# Altium schematic sheet Lobe_ESP32-S3.SchDoc
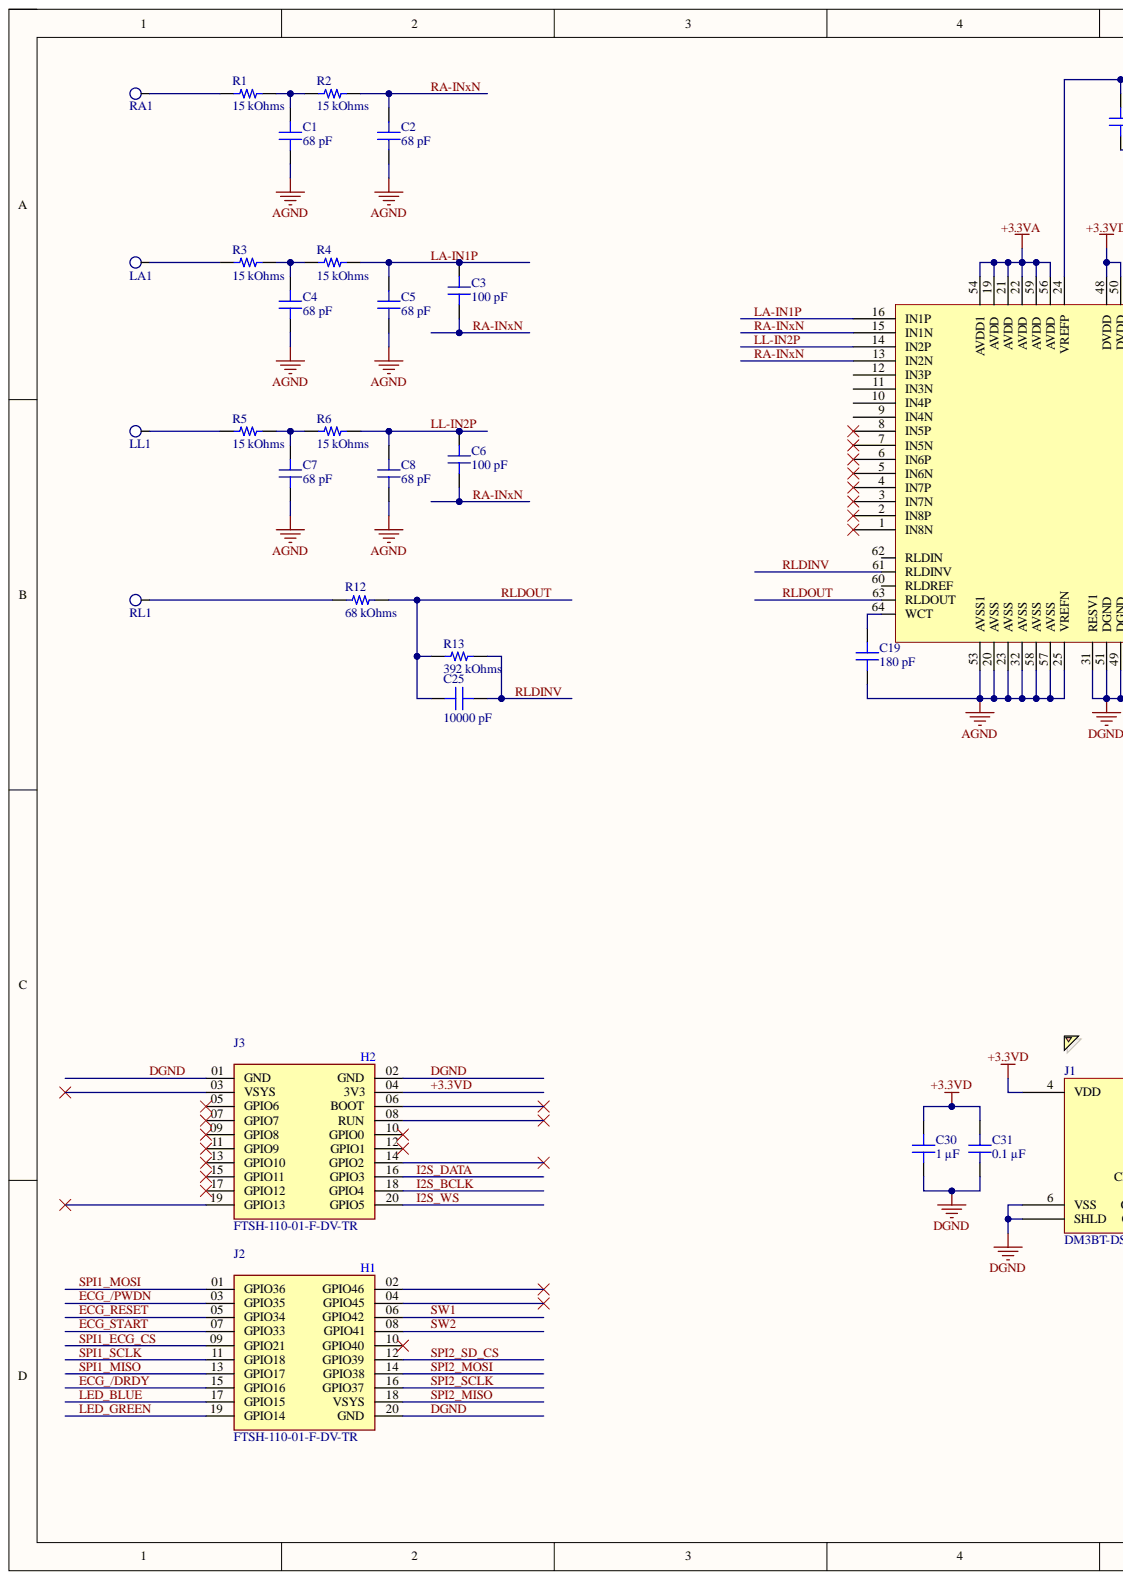
[diagram p0: Lobe_ESP32-S3.SchDoc, left]
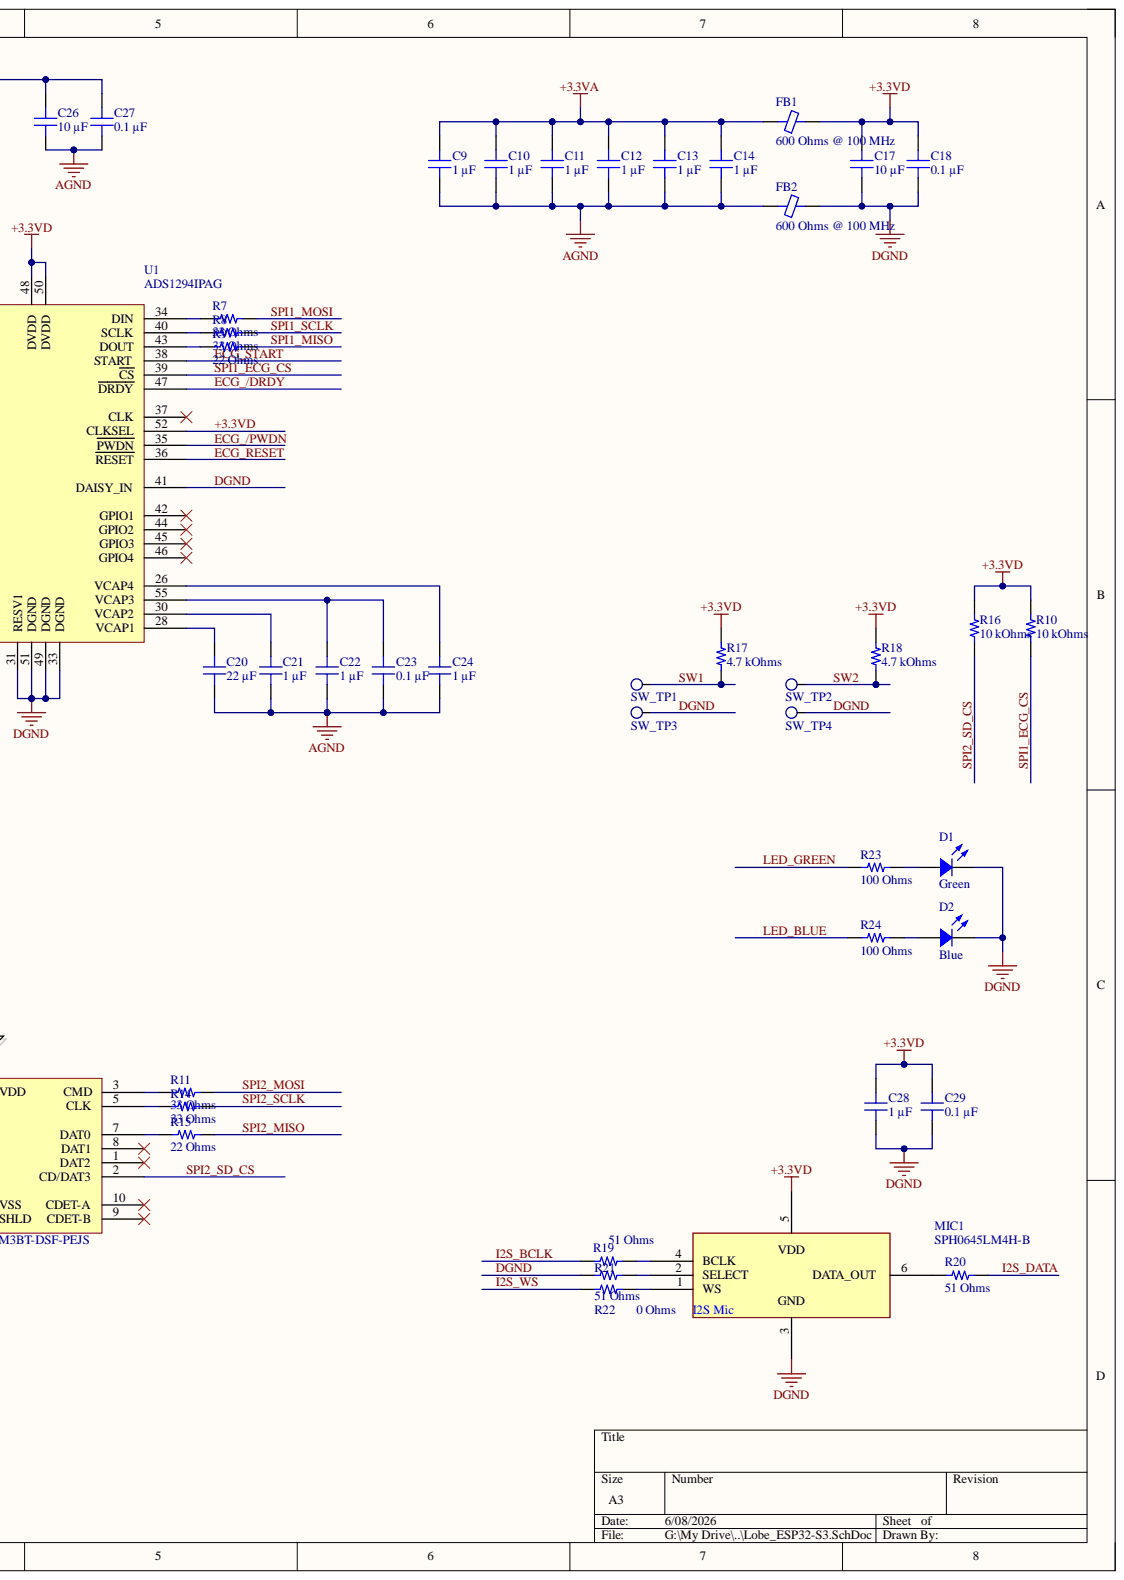
[diagram p1: Lobe_ESP32-S3.SchDoc, right]

## Parts
C1  68 pF  [CAP 0402_1005]
C2  68 pF  [CAP 0402_1005]
C3  100 pF  [CAP 0402_1005]
C4  68 pF  [CAP 0402_1005]
C5  68 pF  [CAP 0402_1005]
C6  100 pF  [CAP 0402_1005]
C7  68 pF  [CAP 0402_1005]
C8  68 pF  [CAP 0402_1005]
C9  1 µF  [CAP 0402_1005]
C10  1 µF  [CAP 0402_1005]
C11  1 µF  [CAP 0402_1005]
C12  1 µF  [CAP 0402_1005]
C13  1 µF  [CAP 0402_1005]
C14  1 µF  [CAP 0402_1005]
C17  10 µF  [CAP 0402_1005]
C18  0.1 µF  [CAP 0402_1005]
C19  180 pF  [CAP 0402_1005]
C20  22 µF  [CAP 0402_1005]
C21  1 µF  [CAP 0402_1005]
C22  1 µF  [CAP 0402_1005]
C23  0.1 µF  [CAP 0402_1005]
C24  1 µF  [CAP 0402_1005]
C25  10000 pF  [CAP 0402_1005]
C26  10 µF  [CAP 0402_1005]
C27  0.1 µF  [CAP 0402_1005]
C28  1 µF  [CAP 0402_1005]
C29  0.1 µF  [CAP 0402_1005]
C30  1 µF  [CAP 0402_1005]
C31  0.1 µF  [CAP 0402_1005]
D1  Green  [LED 0402_1005 GREEN]
D2  Blue  [LED 0402_1005 BLUE]
FB1  600 Ohms @ 100 MHz  [FER 0402_1005]
FB2  600 Ohms @ 100 MHz  [FER 0402_1005]
J1  DM3BT-DSF-PEJS  [HIROSE DM3BT-DSF-PEJS]
J2  FTSH-110-01-F-DV-TR  [SAMTEC_FTSH-110-01-F-DV-TR]
J3  FTSH-110-01-F-DV-TR  [SAMTEC_FTSH-110-01-F-DV-TR]
LA1  TestPoint  [PIAZZOLA]  (value not drawn)
LL1  TestPoint  [PIAZZOLA]  (value not drawn)
MIC1  SPH0645LM4H-B  [SPH0645LM4H-B_KNO]
R1  15 kOhms  [RES 0402_1005]
R2  15 kOhms  [RES 0402_1005]
R3  15 kOhms  [RES 0402_1005]
R4  15 kOhms  [RES 0402_1005]
R5  15 kOhms  [RES 0402_1005]
R6  15 kOhms  [RES 0402_1005]
R7  33 Ohms  [RES 0402_1005]
R8  33 Ohms  [RES 0402_1005]
R9  22 Ohms  [RES 0402_1005]
R10  10 kOhms  [RES 0402_1005]
R11  33 Ohms  [RES 0402_1005]
R12  68 kOhms  [RES 0402_1005]
R13  392 kOhms  [RES 0402_1005]
R14  33 Ohms  [RES 0402_1005]
R15  22 Ohms  [RES 0402_1005]
R16  10 kOhms  [RES 0402_1005]
R17  4.7 kOhms  [RES 0402_1005]
R18  4.7 kOhms  [RES 0402_1005]
R19  51 Ohms  [RES 0402_1005]
R20  51 Ohms  [RES 0402_1005]
R21  0 Ohms  [RES 0402_1005]
R22  51 Ohms  [RES 0402_1005]
R23  100 Ohms  [RES 0402_1005]
R24  100 Ohms  [RES 0402_1005]
RA1  TestPoint  [PIAZZOLA]  (value not drawn)
RL1  TestPoint  [PIAZZOLA]  (value not drawn)
SW_TP1  TestPoint  [PIAZZOLA]  (value not drawn)
SW_TP2  TestPoint  [PIAZZOLA]  (value not drawn)
SW_TP3  TestPoint  [PIAZZOLA]  (value not drawn)
SW_TP4  TestPoint  [PIAZZOLA]  (value not drawn)
U1  ADS1294IPAG  [TSQFP50P1200X1200X120-64N]

## Nets
+3.3VA: C9.2 C10.2 C11.2 C12.2 C13.2 C14.2 FB1.1 U1.19(AVDD) U1.21(AVDD) U1.22(AVDD) U1.54(AVDD1) U1.56(AVDD) U1.59(AVDD)
AGND: C1.1 C2.1 C4.1 C5.1 C7.1 C8.1 C9.1 C10.1 C11.1 C12.1 C13.1 C14.1 C19.1 C20.1 C21.1 C22.1 C23.1 C24.1 C26.1 C27.1 FB2.1 U1.20(AVSS) U1.23(AVSS) U1.25(VREFN) U1.32(AVSS) U1.53(AVSS1) U1.57(AVSS) U1.58(AVSS)
+3.3VD: C17.2 C18.2 C28.2 C29.2 C30.2 C31.2 FB1.2 J1.4(VDD) J3.04(3V3) MIC1.5 R10.2 R16.2 R17.2 R18.2 U1.48(DVDD) U1.50(DVDD) U1.52(CLKSEL)
DGND: C17.1 C18.1 C28.1 C29.1 C30.1 C31.1 D1.2 D2.2 FB2.2 J1.6(VSS) J1.S(SHLD) J2.20(GND) J3.01(GND) J3.02(GND) MIC1.3 R21.1 SW_TP3.1 SW_TP4.1 U1.31(RESV1) U1.33(DGND) U1.41(DAISY_IN) U1.49(DGND) U1.51(DGND)
ECG_/DRDY: J2.15(GPIO16) U1.47(DRDY)
ECG_/PWDN: J2.03(GPIO35) U1.35(PWDN)
ECG_RESET: J2.05(GPIO34) U1.36(RESET)
ECG_START: J2.07(GPIO33) U1.38(START)
I2S_BCLK: J3.18(GPIO4) R19.1
I2S_DATA: J3.16(GPIO3) R20.2
I2S_WS: J3.20(GPIO5) R22.1
LA-IN1P: C3.2 C5.2 R4.2 U1.16(IN1P)
LED_BLUE: J2.17(GPIO15) R24.1
LED_GREEN: J2.19(GPIO14) R23.1
LL-IN2P: C6.2 C8.2 R6.2 U1.14(IN2P)
RA-INxN: C2.2 C3.1 C6.1 R2.2 U1.13(IN2N) U1.15(IN1N)
RLDINV: C25.2 R13.2 U1.61(RLDINV)
RLDOUT: C25.1 R12.2 R13.1 U1.63(RLDOUT)
SPI1_ECG_CS: J2.09(GPIO21) R10.1 U1.39(CS)
SPI1_MISO: J2.13(GPIO17) R9.2
SPI1_MOSI: J2.01(GPIO36) R7.2
SPI1_SCLK: J2.11(GPIO18) R8.2
SPI2_MISO: J2.18(VSYS) R15.2
SPI2_MOSI: J2.14(GPIO38) R11.2
SPI2_SCLK: J2.16(GPIO37) R14.2
SPI2_SD_CS: J1.2(CD/DAT3) J2.12(GPIO39) R16.1
SW1: J2.06(GPIO42) R17.1 SW_TP1.1
SW2: J2.08(GPIO41) R18.1 SW_TP2.1
NetC1_2: C1.2 R1.2 R2.1
NetC4_2: C4.2 R3.2 R4.1
NetC7_2: C7.2 R5.2 R6.1
NetC19_2: C19.2 U1.64(WCT)
NetC20_2: C20.2 U1.28(VCAP1)
NetC21_2: C21.2 U1.30(VCAP2)
NetC22_2: C22.2 C23.2 U1.55(VCAP3)
NetC24_2: C24.2 U1.26(VCAP4)
NetC26_2: C26.2 C27.2 U1.24(VREFP)
NetD1_1: D1.1 R23.2
NetD2_1: D2.1 R24.2
NetJ1_3: J1.3(CMD) R11.1
NetJ1_5: J1.5(CLK) R14.1
NetJ1_7: J1.7(DAT0) R15.1
NetLA1_1: LA1.1 R3.1
NetLL1_1: LL1.1 R5.1
NetMIC1_1: MIC1.1(WS) R22.2
NetMIC1_2: MIC1.2(SELECT) R21.2
NetMIC1_4: MIC1.4(BCLK) R19.2
NetMIC1_6: MIC1.6(DATA_OUT) R20.1
NetR1_1: R1.1 RA1.1
NetR7_1: R7.1 U1.34(DIN)
NetR8_1: R8.1 U1.40(SCLK)
NetR9_1: R9.1 U1.43(DOUT)
NetR12_1: R12.1 RL1.1

Not connected: J1 1(DAT2) 8(DAT1) 9(CDET-B) 10(CDET-A); J2 02(GPIO46) 04(GPIO45) 10(GPIO40); J3 03(VSYS) 05(GPIO6) 06(BOOT) 07(GPIO7) 08(RUN) 09(GPIO8) 10(GPIO0) 11(GPIO9) 12(GPIO1) 13(GPIO10) 14(GPIO2) 15(GPIO11) 17(GPIO12) 19(GPIO13); U1 1(IN8N) 2(IN8P) 3(IN7N) 4(IN7P) 5(IN6N) 6(IN6P) 7(IN5N) 8(IN5P) 9(IN4N) 10(IN4P) 11(IN3N) 12(IN3P) 37(CLK) 42(GPIO1) 44(GPIO2) 45(GPIO3) 46(GPIO4) 60(RLDREF) 62(RLDIN)

## Library data of the parts
C1 C2 C4 C5 C7 C8 = C0402C680J5GACAUTO: CAP CER 68PF 50V C0G/NP0 0402; manufacturer: KEMET; Database Table Name: Capacitors - Ceramic - 0402; Temperature Coefficient: C0G, NP0; Tolerance: ±5%; Applications: Automotive; Capacitance: 68 pF; Component Kind: Standard; componentlink1description: Datasheet; componentlink1url: https://api.kemet.com/component-edge/download/datasheet/C0402C680J5GACAUTO.pdf; componentlink2description: DigiKey Link; componentlink2url: https://www.digikey.com/en/products/detail/kemet/C0402C680J5GACAUTO/7516109; Device Package: 0402 (1005 Metric); Features: Low ESL; lastupdated: 05/04/2026 19:11:09; Library Name: Celestial Altium Library - altium_library.DbLib; Lifecycle Status: Active; Minimum Order: 1; Mounting Type: Surface Mount, MLCC; Operating Temperature: -55°C ~ 125°C; Package / Case: 0402 (1005 Metric); packaging: TapeAndReel; Part Number: C0402C680J5GACAUTO; Part Status: Active; partid: 2086; price: 0.01; Ratings: AEC-Q200; series: SMD Auto C0G; Size / Dimension: 0.039" L x 0.020" W (1.00mm x 0.50mm); Supplier 1: DigiKey; Supplier Part Number 1: 399-15875-1-ND; Thickness (Max): 0.022" (0.55mm); value: 68 pF; Voltage - Rated: 50V
C3 C6 = C0402C101F3GAC7867: CAP CER 100PF 25V C0G/NP0 0402; manufacturer: KEMET; Database Table Name: Capacitors - Ceramic - 0402; Temperature Coefficient: C0G, NP0; Tolerance: ±1%; Applications: General Purpose; Capacitance: 100 pF; Component Kind: Standard; componentlink1description: Datasheet; componentlink1url: https://api.kemet.com/component-edge/download/datasheet/C0402C101F3GACTU.pdf; componentlink2description: DigiKey Link; componentlink2url: https://www.digikey.com/en/products/detail/kemet/C0402C101F3GAC7867/2196160; Device Package: 0402 (1005 Metric); Features: Low ESL; lastupdated: 05/04/2026 19:10:07; Library Name: Celestial Altium Library - altium_library.DbLib; Lifecycle Status: Active; Minimum Order: 1; Mounting Type: Surface Mount, MLCC; Operating Temperature: -55°C ~ 125°C; Package / Case: 0402 (1005 Metric); packaging: TapeAndReel; Part Number: C0402C101F3GAC7867; Part Status: Active; partid: 33146; price: 0.39; series: SMD Comm C0G; Size / Dimension: 0.039" L x 0.020" W (1.00mm x 0.50mm); Supplier 1: DigiKey; Supplier Part Number 1: 399-C0402C101F3GAC7867CT-ND; Thickness (Max): 0.022" (0.55mm); value: 100 pF; Voltage - Rated: 25V
C9-C14 C21 C22 C24 C28 C30 = GMC04X5R105K50NT: CAP0402 X5R 1UF 10% 50V; manufacturer: Cal-Chip Electronics, Inc.; Database Table Name: Capacitors - Ceramic - 0402; Applications: General Purpose; Capacitance: 1 µF; Component Kind: Standard; componentlink1description: Datasheet; componentlink1url: https://calchip.com/wp-content/uploads/2023/05/gmc_series.pdf; componentlink2description: DigiKey Link; componentlink2url: https://www.digikey.com/en/products/detail/cal-chip-electronics-inc/GMC04X5R105K50NT/21802043; Device Package: 0402 (1005 Metric); lastupdated: 05/04/2026 19:07:28; Library Name: Celestial Altium Library - altium_library.DbLib; Lifecycle Status: Active; Minimum Order: 1; Mounting Type: Surface Mount, MLCC; Operating Temperature: -55°C ~ 85°C; Package / Case: 0402 (1005 Metric); packaging: TapeAndReel; Part Number: GMC04X5R105K50NT; partid: 63715; price: 0.02; series: GMC; Size / Dimension: 0.039" L x 0.020" W (1.00mm x 0.50mm); Supplier 1: DigiKey; Supplier Part Number 1: 4713-GMC04X5R105K50NTCT-ND; Temperature Coefficient: X5R; Thickness (Max): 0.022" (0.55mm); Tolerance: ±10%; value: 1 µF; Voltage - Rated: 50V
C17 C26 = C0402C106M9PAC7867: CAP CER 10UF 6.3V X5R 0402; manufacturer: KEMET; Database Table Name: Capacitors - Ceramic - 0402; Tolerance: ±20%; Applications: Bypass, Decoupling; Capacitance: 10 µF; Component Kind: Standard; componentlink1description: Datasheet; componentlink1url: https://api.kemet.com/component-edge/download/datasheet/C0402C106M9PAC7867.pdf; componentlink2description: DigiKey Link; componentlink2url: https://www.digikey.com/en/products/detail/kemet/C0402C106M9PAC7867/5767552; Device Package: 0402 (1005 Metric); lastupdated: 05/04/2026 19:11:04; Library Name: Celestial Altium Library - altium_library.DbLib; Lifecycle Status: Active; Minimum Order: 1; Mounting Type: Surface Mount, MLCC; Operating Temperature: -55°C ~ 85°C; Package / Case: 0402 (1005 Metric); packaging: TapeAndReel; Part Number: C0402C106M9PAC7867; Part Status: Active; partid: 41493; price: 0.12; series: SMD Comm X5R; Size / Dimension: 0.039" L x 0.020" W (1.00mm x 0.50mm); Supplier 1: DigiKey; Supplier Part Number 1: 399-13069-1-ND; Temperature Coefficient: X5R; Thickness (Max): 0.028" (0.70mm); value: 10 µF; Voltage - Rated: 6.3V
C18 C23 C27 C29 C31 = CL05B104KP5VPNC: CAP CER 100NF 10V X7R 0402; manufacturer: Samsung Electro-Mechanics; Database Table Name: Capacitors - Ceramic - 0402; Tolerance: ±10%; Applications: Automotive; Capacitance: 0.1 µF; Component Kind: Standard; componentlink1description: Datasheet; componentlink1url: https://mm.digikey.com/Volume0/opasdata/d220001/medias/docus/5458/CL05B104KP5VPNC_Spec.pdf; componentlink2description: DigiKey Link; componentlink2url: https://www.digikey.com/en/products/detail/samsung-electro-mechanics/CL05B104KP5VPNC/20498482; Device Package: 0402 (1005 Metric); Features: General Purpose; lastupdated: 05/04/2026 19:07:41; Library Name: Celestial Altium Library - altium_library.DbLib; Lifecycle Status: Active; Minimum Order: 1; Mounting Type: Surface Mount, MLCC, Epoxy; Operating Temperature: -55°C ~ 125°C; Package / Case: 0402 (1005 Metric); packaging: TapeAndReel; Part Number: CL05B104KP5VPNC; partid: 56690; price: 0.01; Ratings: AEC-Q200; series: CL; Size / Dimension: 0.039" L x 0.020" W (1.00mm x 0.50mm); Supplier 1: DigiKey; Supplier Part Number 1: 1276-CL05B104KP5VPNCCT-ND; Temperature Coefficient: X7R; Thickness (Max): 0.022" (0.55mm); value: 0.1 µF; Voltage - Rated: 10V
C19 = C0402C181J5GACAUTO: CAP CER 180PF 50V C0G/NP0 0402; manufacturer: KEMET; Database Table Name: Capacitors - Ceramic - 0402; Temperature Coefficient: C0G, NP0; Tolerance: ±5%; Applications: Automotive; Capacitance: 180 pF; Component Kind: Standard; componentlink1description: Datasheet; componentlink1url: https://api.kemet.com/component-edge/download/datasheet/C0402C181J5GACAUTO.pdf; componentlink2description: DigiKey Link; componentlink2url: https://www.digikey.com/en/products/detail/kemet/C0402C181J5GACAUTO/3314831; Device Package: 0402 (1005 Metric); Features: Low ESL; lastupdated: 05/04/2026 19:10:56; Library Name: Celestial Altium Library - altium_library.DbLib; Lifecycle Status: Active; Minimum Order: 1; Mounting Type: Surface Mount, MLCC; Operating Temperature: -55°C ~ 125°C; Package / Case: 0402 (1005 Metric); packaging: TapeAndReel; Part Number: C0402C181J5GACAUTO; Part Status: Active; partid: 5144; price: 0.01; Ratings: AEC-Q200; series: SMD Auto C0G; Size / Dimension: 0.039" L x 0.020" W (1.00mm x 0.50mm); Supplier 1: DigiKey; Supplier Part Number 1: 399-6832-1-ND; Thickness (Max): 0.022" (0.55mm); value: 180 pF; Voltage - Rated: 50V
C20 = GMC04X5R226M6R3NT: CAP0402 X5R 22UF 20% 6.3V; manufacturer: Cal-Chip Electronics, Inc.; Database Table Name: Capacitors - Ceramic - 0402; Applications: General Purpose; Capacitance: 22 µF; Component Kind: Standard; componentlink1description: Datasheet; componentlink1url: https://88ce57.p3cdn1.secureserver.net/wp-content/uploads/2023/01/gmc_series-2.pdf; componentlink2description: DigiKey Link; componentlink2url: https://www.digikey.com/en/products/detail/cal-chip-electronics-inc/GMC04X5R226M6R3NT/18151129; Device Package: 0402 (1005 Metric); lastupdated: 05/04/2026 19:09:29; Library Name: Celestial Altium Library - altium_library.DbLib; Lifecycle Status: Active; Minimum Order: 1; Mounting Type: Surface Mount, MLCC; Operating Temperature: -55°C ~ 85°C; Package / Case: 0402 (1005 Metric); packaging: TapeAndReel; Part Number: GMC04X5R226M6R3NT; Part Status: Active; partid: 49823; price: 0.08; series: GMC; Size / Dimension: 0.039" L x 0.020" W (1.00mm x 0.50mm); Supplier 1: DigiKey; Supplier Part Number 1: 4713-GMC04X5R226M6R3NTCT-ND; Temperature Coefficient: X5R; Thickness (Max): 0.031" (0.80mm); Tolerance: ±20%; value: 22 µF; Voltage - Rated: 6.3V
C25 = C0402C103J3RECAUTO: CAP CER 0402 10NF 25V X7R 5%; manufacturer: KEMET; Database Table Name: Capacitors - Ceramic - 0402; Applications: Automotive, ESD Protection; Capacitance: 10000 pF; Component Kind: Standard; componentlink1description: Datasheet; componentlink1url: https://connect.kemet.com:7667/gateway/IntelliData-ComponentDocumentation/1.0/download/datasheet/C0402C103J3RECAUTO; componentlink2description: DigiKey Link; componentlink2url: https://www.digikey.com/en/products/detail/kemet/C0402C103J3RECAUTO/8646437; Device Package: 0402 (1005 Metric); lastupdated: 05/04/2026 19:07:35; Library Name: Celestial Altium Library - altium_library.DbLib; Lifecycle Status: Active; Minimum Order: 1; Mounting Type: Surface Mount, MLCC; Operating Temperature: -55°C ~ 125°C; Package / Case: 0402 (1005 Metric); packaging: TapeAndReel; Part Number: C0402C103J3RECAUTO; partid: 64019; price: 0.02; Ratings: AEC-Q200; series: ESD SMD Auto X7R; Size / Dimension: 0.039" L x 0.020" W (1.00mm x 0.50mm); Supplier 1: DigiKey; Supplier Part Number 1: 399-C0402C103J3RECAUTOCT-ND; Temperature Coefficient: X7R; Thickness (Max): 0.022" (0.55mm); Tolerance: ±5%; value: 10000 pF; Voltage - Rated: 25V
D1 = SMLP14ECNWT86: LED GREEN DIFFUSED 0402 SMD; Manufacturer: Rohm Semiconductor; Current - Test: 5mA; Database Table Name: LED - Indication; Lens Color: White; Lens Size: 1.00mm x 0.60mm; Lens Style: Rectangle with Flat Top; Millicandela Rating: 110mcd; Voltage - Forward (Vf) (Typ): 3V; Wavelength - Dominant: 527nm; Color: Green; Component Kind: Standard; ComponentLink1Description: Datasheet; ComponentLink1URL: https://fscdn.rohm.com/en/products/databook/datasheet/opto/led/chip_mono/smlp14ecnwt86-e.pdf; ComponentLink2Description: DigiKey Link; ComponentLink2URL: https://www.digikey.com/en/products/detail/rohm-semiconductor/SMLP14ECNWT86/16808213; Device Package: 0402 (1005 Metric); Height (Max): 0.25mm; LastUpdated: 05/04/2026 20:35:37; Lens Transparency: Diffused; Library Name: Celestial Altium Library - altium_library.DbLib; Lifecycle Status: Active; Minimum Order: 1; Mounting Type: Surface Mount; Package / Case: 0402 (1005 Metric); Packaging: TapeAndReel; Part Number: SMLP14ECNWT86; Part Status: Active; PartId: 1225; Price: 0.34; Series: -; Size / Dimension: 1.00mm L x 0.60mm W; Supplier 1: DigiKey; Supplier Device Package: 0402; Supplier Part Number 1: 846-SMLP14ECNWT86CT-ND; Value: Green
D2 = SMLP14BCNWT86: LED BLUE DIFFUSED 0402 SMD; Manufacturer: Rohm Semiconductor; Current - Test: 5mA; Database Table Name: LED - Indication; Lens Color: White; Lens Size: 1.00mm x 0.60mm; Lens Style: Rectangle with Flat Top; Millicandela Rating: 25mcd; Voltage - Forward (Vf) (Typ): 2.9V; Wavelength - Dominant: 470nm; Color: Blue; Component Kind: Standard; ComponentLink2Description: DigiKey Link; ComponentLink2URL: https://www.digikey.com/en/products/detail/rohm-semiconductor/SMLP14BCNWT86/15792854; Device Package: 0402 (1005 Metric); Height (Max): 0.25mm; LastUpdated: 05/04/2026 20:34:30; Lens Transparency: Diffused; Library Name: Celestial Altium Library - altium_library.DbLib; Lifecycle Status: Active; Minimum Order: 1; Mounting Type: Surface Mount; Package / Case: 0402 (1005 Metric); Packaging: TapeAndReel; Part Number: SMLP14BCNWT86; Part Status: Active; PartId: 1297; Price: 0.17; Series: -; Size / Dimension: 1.00mm L x 0.60mm W; Supplier 1: DigiKey; Supplier Device Package: 0402; Supplier Part Number 1: 846-SMLP14BCNWT86CT-ND; Value: Blue
FB1 FB2 = BLM15PX601SN1D: FERRITE BEAD 600 OHM 0402 1LN; Manufacturer: Murata Electronics; Current Rating (Max): 900mA; Database Table Name: Filters - Ferrite Beads and Chips; DC Resistance (DCR) (Max): 230mOhm; Filter Type: Power Line; Number of Lines: 1; Component Kind: Standard; ComponentLink1Description: Datasheet; ComponentLink1URL: https://www.murata.com/en-us/products/productdata/8796740059166/ENFA0018.pdf; ComponentLink2Description: DigiKey Link; ComponentLink2URL: https://www.digikey.com/en/products/detail/murata-electronics/BLM15PX601SN1D/4421102; Device Package: 0402 (1005 Metric); Height (Max): 0.022" (0.55mm); Impedance @ Frequency: 600 Ohms @ 100 MHz; LastUpdated: 05/04/2026 20:27:16; Library Name: Celestial Altium Library - altium_library.DbLib; Lifecycle Status: Active; Minimum Order: 1; Mounting Type: Surface Mount; Operating Temperature: -55°C ~ 125°C; Package / Case: 0402 (1005 Metric); Packaging: TapeAndReel; Part Number: BLM15PX601SN1D; Part Status: Active; PartId: 188; Price: 0.02; Series: EMIFIL®, BLM15; Size / Dimension: 0.039" L x 0.022" W (1.00mm x 0.55mm); Supplier 1: DigiKey; Supplier Part Number 1: 490-9657-1-ND; Value: 600 Ohms @ 100 MHz
J1 = DM3BT-DSF-PEJS: CONN MICRO SD CARD PUSH-PUSH R/A; Manufacturer: Hirose Electric Co Ltd; Card Type: Secure Digital - microSD™; Connector Type: Connector and Ejector; Database Table Name: Connectors - Memory - Card Sockets; Insertion, Removal Method: Push In, Push Out; Number of Positions: 10 (8 + 2); Component Kind: Standard; ComponentLink1Description: Datasheet; ComponentLink1URL: https://www.hirose.com/product/download/?distributor=digikey&type=specSheet&lang=en&num=DM3BT-DSF-PEJS; ComponentLink2Description: DigiKey Link; ComponentLink2URL: //digikey.com/product-detail/en/hirose-electric-co-ltd/DM3BT-DSF-PEJS/HR1942TR-ND/1982749; Contact Finish: Gold; Features: Switch; Height Above Board: 0.072" (1.83mm); LastUpdated: 16/12/2019 20:35:34; Library Name: Celestial Altium Library - altium_library.DbLib; Lifecycle Status: 0; Minimum Order: 1; Mounting Feature: Reverse - Bottom; Mounting Type: Surface Mount, Right Angle; Packaging: TapeAndReel; Part Number: DM3BT-DSF-PEJS; Part Status: Active; Price: 1.25; Series: DM3; Supplier 1: DigiKey; Supplier Part Number 1: HR1942TR-ND
J2 = H1-ESP32-S3-LCD-1.28: Connector Header Surface Mount 20 position 0.050 (1.27mm); MF: Samtec; MP: FTSH-110-01-F-DV-P-TR; Check_prices: https://www.snapeda.com/parts/FTSH-110-01-F-DV-P-TR/Samtec%20Inc./view-part/2789086/?ref=eda; Component Kind: Standard; Digi-Key_Part_Number: SAM13954CT-ND; Package: None; Purchase-URL: https://pricing.snapeda.com/search/part/FTSH-110-01-F-DV-P-TR/?ref=eda; SnapEDA_Link: https://www.snapeda.com/parts/FTSH-110-01-F-DV-P-TR/Samtec%20Inc./view-part/2789086/?ref=snap; Library Name: Lobe_Lib.SchLib
J3 = H2-ESP32-S3-LCD-1.28: Connector Header Surface Mount 20 position 0.050 (1.27mm); MF: Samtec; MP: FTSH-110-01-F-DV-P-TR; Check_prices: https://www.snapeda.com/parts/FTSH-110-01-F-DV-P-TR/Samtec%20Inc./view-part/2789086/?ref=eda; Component Kind: Standard; Digi-Key_Part_Number: SAM13954CT-ND; Package: None; Purchase-URL: https://pricing.snapeda.com/search/part/FTSH-110-01-F-DV-P-TR/?ref=eda; SnapEDA_Link: https://www.snapeda.com/parts/FTSH-110-01-F-DV-P-TR/Samtec%20Inc./view-part/2789086/?ref=snap; Library Name: Lobe_Lib.SchLib
MIC1 = SPH0645LM4H-B: No Description Available; Manufacturer_Name: Knowles; Component Kind: Standard; Manufacturer_Part_Number: SPH0645LM4H-B; RefDes: RefDes; Type: Type; Copyright: Copyright (C) 2026 Ultra Librarian. All rights reserved.; Library Name: Lobe_Lib.SchLib
R1-R6 = AC0402FR-0715KL: RES SMD 15K OHM 1% 1/16W 0402; Manufacturer: Yageo; Database Table Name: Resistors - Surface Mount - 0402; Power (Watts): 0.063W, 1/16W; Temperature Coefficient: ±100ppm/°C; Component Kind: Standard; ComponentLink1Description: Datasheet; ComponentLink1URL: https://www.yageo.com/upload/media/product/productsearch/datasheet/rchip/PYu-AC_51_RoHS_L_7.pdf; ComponentLink2Description: DigiKey Link; ComponentLink2URL: https://www.digikey.com/en/products/detail/yageo/AC0402FR-0715KL/5895086; Composition: Thick Film; Device Package: 0402 (1005 Metric); Features: Automotive AEC-Q200, Moisture Resistant; Height - Seated (Max): 0.015" (0.37mm); LastUpdated: 06/04/2026 19:41:11; Library Name: Celestial Altium Library - altium_library.DbLib; Lifecycle Status: Active; Minimum Order: 1; Number of Terminations: 2; Operating Temperature: -55°C ~ 155°C; Package / Case: 0402 (1005 Metric); Packaging: CutTape; Part Number: AC0402FR-0715KL; Part Status: Active; PartId: 12138; Price: 0.01; Ratings: AEC-Q200; Resistance: 15 kOhms; Series: AC; Size / Dimension: 0.039" L x 0.020" W (1.00mm x 0.50mm); Supplier 1: DigiKey; Supplier Device Package: 0402; Supplier Part Number 1: YAG3444CT-ND; Tolerance: ±1%; Value: 15 kOhms
R7 R8 R11 R14 = RC0402FR-0733RP: RES 33 OHM 1% 1/16W 0402; Manufacturer: Yageo; Database Table Name: Resistors - Surface Mount - 0402; Power (Watts): 0.063W, 1/16W; Ratings: 7C1 RoHS w/out Exemption (100% Pb-Free); Temperature Coefficient: ±100ppm/°C; Component Kind: Standard; ComponentLink1Description: Datasheet; ComponentLink1URL: http://www.yageo.com/NewPortal/yageodocoutput?fileName=/pdf/R-Chip/PYu-RC_51_RoHS_P_0.pdf; ComponentLink2Description: DigiKey Link; ComponentLink2URL: https://www.digikey.com/en/products/detail/yageo/RC0402FR-0733RP/4935279; Composition: Thick Film; Device Package: 0402 (1005 Metric); Features: Moisture Resistant; Height - Seated (Max): 0.016" (0.40mm); LastUpdated: 06/04/2026 19:41:12; Library Name: Celestial Altium Library - altium_library.DbLib; Lifecycle Status: Active; Minimum Order: 1; Number of Terminations: 2; Operating Temperature: -55°C ~ 155°C; Package / Case: 0402 (1005 Metric); Packaging: CutTape; Part Number: RC0402FR-0733RP; Part Status: Active; PartId: 9331; Price: 0.01; Resistance: 33 Ohms; Series: RC_P; Size / Dimension: 0.039" L x 0.020" W (1.00mm x 0.50mm); Supplier 1: DigiKey; Supplier Device Package: 0402; Supplier Part Number 1: YAG1264CT-ND; Tolerance: ±1%; Value: 33 Ohms
R9 R15 = RC0402FR-0722RP: RES 22 OHM 1% 1/16W 0402; Manufacturer: Yageo; Database Table Name: Resistors - Surface Mount - 0402; Power (Watts): 0.063W, 1/16W; Ratings: 7C1 RoHS w/out Exemption (100% Pb-Free); Temperature Coefficient: ±100ppm/°C; Component Kind: Standard; ComponentLink1Description: Datasheet; ComponentLink1URL: http://www.yageo.com/NewPortal/yageodocoutput?fileName=/pdf/R-Chip/PYu-RC_51_RoHS_P_0.pdf; ComponentLink2Description: DigiKey Link; ComponentLink2URL: https://www.digikey.com/en/products/detail/yageo/RC0402FR-0722RP/4935272; Composition: Thick Film; Device Package: 0402 (1005 Metric); Features: Moisture Resistant; Height - Seated (Max): 0.016" (0.40mm); LastUpdated: 06/04/2026 19:41:08; Library Name: Celestial Altium Library - altium_library.DbLib; Lifecycle Status: Active; Minimum Order: 1; Number of Terminations: 2; Operating Temperature: -55°C ~ 155°C; Package / Case: 0402 (1005 Metric); Packaging: CutTape; Part Number: RC0402FR-0722RP; Part Status: Active; PartId: 16168; Price: 0.01; Resistance: 22 Ohms; Series: RC_P; Size / Dimension: 0.039" L x 0.020" W (1.00mm x 0.50mm); Supplier 1: DigiKey; Supplier Device Package: 0402; Supplier Part Number 1: YAG1257CT-ND; Tolerance: ±1%; Value: 22 Ohms
R10 R16 = AC0402FR-0710KL: RES SMD 10K OHM 1% 1/16W 0402; Manufacturer: Yageo; Database Table Name: Resistors - Surface Mount - 0402; Power (Watts): 0.063W, 1/16W; Temperature Coefficient: ±100ppm/°C; Component Kind: Standard; ComponentLink1Description: Datasheet; ComponentLink1URL: https://www.yageo.com/upload/media/product/productsearch/datasheet/rchip/PYu-AC_51_RoHS_L_7.pdf; ComponentLink2Description: DigiKey Link; ComponentLink2URL: https://www.digikey.com/en/products/detail/yageo/AC0402FR-0710KL/5895030; Composition: Thick Film; Device Package: 0402 (1005 Metric); Features: Automotive AEC-Q200, Moisture Resistant; Height - Seated (Max): 0.015" (0.37mm); LastUpdated: 06/04/2026 19:41:12; Library Name: Celestial Altium Library - altium_library.DbLib; Lifecycle Status: Active; Minimum Order: 1; Number of Terminations: 2; Operating Temperature: -55°C ~ 155°C; Package / Case: 0402 (1005 Metric); Packaging: CutTape; Part Number: AC0402FR-0710KL; Part Status: Active; PartId: 12029; Price: 0.01; Ratings: AEC-Q200; Resistance: 10 kOhms; Series: AC; Size / Dimension: 0.039" L x 0.020" W (1.00mm x 0.50mm); Supplier 1: DigiKey; Supplier Device Package: 0402; Supplier Part Number 1: YAG3436CT-ND; Tolerance: ±1%; Value: 10 kOhms
R12 = RC0402FR-0768KL: RES 68K OHM 1% 1/16W 0402; Manufacturer: Yageo; Database Table Name: Resistors - Surface Mount - 0402; Power (Watts): 0.063W, 1/16W; Temperature Coefficient: ±100ppm/°C; Component Kind: Standard; ComponentLink1Description: Datasheet; ComponentLink1URL: https://www.yageo.com/upload/media/product/productsearch/datasheet/rchip/PYu-RC_Group_51_RoHS_L_10.pdf; ComponentLink2Description: DigiKey Link; ComponentLink2URL: https://www.digikey.com/en/products/detail/yageo/RC0402FR-0768KL/726651; Composition: Thick Film; Device Package: 0402 (1005 Metric); Features: Moisture Resistant; Height - Seated (Max): 0.016" (0.40mm); LastUpdated: 06/04/2026 19:41:19; Library Name: Celestial Altium Library - altium_library.DbLib; Lifecycle Status: Active; Minimum Order: 1; Number of Terminations: 2; Operating Temperature: -55°C ~ 155°C; Package / Case: 0402 (1005 Metric); Packaging: CutTape; Part Number: RC0402FR-0768KL; Part Status: Active; PartId: 2524; Price: 0.01; Resistance: 68 kOhms; Series: RC_L; Size / Dimension: 0.039" L x 0.020" W (1.00mm x 0.50mm); Supplier 1: DigiKey; Supplier Device Package: 0402; Supplier Part Number 1: 311-68.0KLRCT-ND; Tolerance: ±1%; Value: 68 kOhms
R13 = RC0402FR-07392KL: RES 392K OHM 1% 1/16W 0402; Manufacturer: Yageo; Database Table Name: Resistors - Surface Mount - 0402; Power (Watts): 0.063W, 1/16W; Temperature Coefficient: ±100ppm/°C; Component Kind: Standard; ComponentLink1Description: Datasheet; ComponentLink1URL: https://www.yageo.com/upload/media/product/productsearch/datasheet/rchip/PYu-RC_Group_51_RoHS_L_10.pdf; ComponentLink2Description: DigiKey Link; ComponentLink2URL: https://www.digikey.com/en/products/detail/yageo/RC0402FR-07392KL/5281004; Composition: Thick Film; Device Package: 0402 (1005 Metric); Features: Moisture Resistant; Height - Seated (Max): 0.016" (0.40mm); LastUpdated: 06/04/2026 19:41:18; Library Name: Celestial Altium Library - altium_library.DbLib; Lifecycle Status: Active; Minimum Order: 1; Number of Terminations: 2; Operating Temperature: -55°C ~ 155°C; Package / Case: 0402 (1005 Metric); Packaging: CutTape; Part Number: RC0402FR-07392KL; Part Status: Active; PartId: 2656; Price: 0.01; Resistance: 392 kOhms; Series: RC_L; Size / Dimension: 0.039" L x 0.020" W (1.00mm x 0.50mm); Supplier 1: DigiKey; Supplier Device Package: 0402; Supplier Part Number 1: YAG3144CT-ND; Tolerance: ±1%; Value: 392 kOhms
R17 R18 = RC0402FR-7W4K7L: RES 4.7K OHM 1% 1/8W 0402; Manufacturer: Yageo; Database Table Name: Resistors - Surface Mount - 0402; Power (Watts): 0.125W, 1/8W; Temperature Coefficient: ±200ppm/°C; Component Kind: Standard; ComponentLink1Description: Datasheet; ComponentLink1URL: https://www.yageo.com/upload/media/product/products/datasheet/rchip/PYu-RC_Group_51_RoHS_L_12.pdf; ComponentLink2Description: DigiKey Link; ComponentLink2URL: https://www.digikey.com/en/products/detail/yageo/RC0402FR-7W4K7L/17019906; Composition: Thick Film; Device Package: 0402 (1005 Metric); Features: Moisture Resistant; Height - Seated (Max): 0.016" (0.40mm); LastUpdated: 06/04/2026 19:40:33; Library Name: Celestial Altium Library - altium_library.DbLib; Lifecycle Status: Active; Minimum Order: 1; Number of Terminations: 2; Operating Temperature: -55°C ~ 155°C; Package / Case: 0402 (1005 Metric); Packaging: CutTape; Part Number: RC0402FR-7W4K7L; PartId: 85108; Price: 0.01; Resistance: 4.7 kOhms; Series: RC_L; Size / Dimension: 0.039" L x 0.020" W (1.00mm x 0.50mm); Supplier 1: DigiKey; Supplier Device Package: 0402; Supplier Part Number 1: 13-RC0402FR-7W4K7LCT-ND; Tolerance: ±1%; Value: 4.7 kOhms
R19 R20 R22 = RC0402FR-0751RL: RES 51 OHM 1% 1/16W 0402; Manufacturer: Yageo; Database Table Name: Resistors - Surface Mount - 0402; Power (Watts): 0.063W, 1/16W; Temperature Coefficient: ±100ppm/°C; Component Kind: Standard; ComponentLink1Description: Datasheet; ComponentLink1URL: https://www.yageo.com/upload/media/product/productsearch/datasheet/rchip/PYu-RC_Group_51_RoHS_L_10.pdf; ComponentLink2Description: DigiKey Link; ComponentLink2URL: https://www.digikey.com/en/products/detail/yageo/RC0402FR-0751RL/726629; Composition: Thick Film; Device Package: 0402 (1005 Metric); Features: Moisture Resistant; Height - Seated (Max): 0.016" (0.40mm); LastUpdated: 06/04/2026 19:41:06; Library Name: Celestial Altium Library - altium_library.DbLib; Lifecycle Status: Active; Minimum Order: 1; Number of Terminations: 2; Operating Temperature: -55°C ~ 155°C; Package / Case: 0402 (1005 Metric); Packaging: CutTape; Part Number: RC0402FR-0751RL; Part Status: Active; PartId: 17999; Price: 0.01; Resistance: 51 Ohms; Series: RC_L; Size / Dimension: 0.039" L x 0.020" W (1.00mm x 0.50mm); Supplier 1: DigiKey; Supplier Device Package: 0402; Supplier Part Number 1: 311-51.0LRCT-ND; Tolerance: ±1%; Value: 51 Ohms
R21 = RC0402JR-070RP: RES 0 OHM JUMPER - 0402; Manufacturer: Yageo; Database Table Name: Resistors - Surface Mount - 0402; Power (Watts): 0.063W, 1/16W; Ratings: 7C1 RoHS w/out Exemption (100% Pb-Free); Component Kind: Standard; ComponentLink1Description: Datasheet; ComponentLink1URL: http://www.yageo.com/NewPortal/yageodocoutput?fileName=/pdf/R-Chip/PYu-RC_51_RoHS_P_0.pdf; ComponentLink2Description: DigiKey Link; ComponentLink2URL: https://www.digikey.com/en/products/detail/yageo/RC0402JR-070RP/4935294; Composition: Thick Film; Device Package: 0402 (1005 Metric); Features: Moisture Resistant; Height - Seated (Max): 0.016" (0.40mm); LastUpdated: 06/04/2026 19:41:06; Library Name: Celestial Altium Library - altium_library.DbLib; Lifecycle Status: Active; Minimum Order: 1; Number of Terminations: 2; Operating Temperature: -55°C ~ 155°C; Package / Case: 0402 (1005 Metric); Packaging: CutTape; Part Number: RC0402JR-070RP; Part Status: Active; PartId: 18920; Price: 0.01; Resistance: 0 Ohms; Series: RC_P; Size / Dimension: 0.039" L x 0.020" W (1.00mm x 0.50mm); Supplier 1: DigiKey; Supplier Device Package: 0402; Supplier Part Number 1: YAG1279CT-ND; Tolerance: Jumper; Value: 0 Ohms
R23 R24 = RC0402FR-13100RP: RES 100 OHM 1% 1/16W 0402; Manufacturer: Yageo; Database Table Name: Resistors - Surface Mount - 0402; Power (Watts): 0.063W, 1/16W; Ratings: 7C1 RoHS w/out Exemption (100% Pb-Free); Temperature Coefficient: ±100ppm/°C; Component Kind: Standard; ComponentLink1Description: Datasheet; ComponentLink1URL: https://www.yageo.com/upload/media/product/productsearch/datasheet/rchip/PYu-RC_51_RoHS_P_5.pdf; ComponentLink2Description: DigiKey Link; ComponentLink2URL: https://www.digikey.com/en/products/detail/yageo/RC0402FR-13100RP/17008601; Composition: Thick Film; Device Package: 0402 (1005 Metric); Height - Seated (Max): 0.016" (0.40mm); LastUpdated: 06/04/2026 19:40:46; Library Name: Celestial Altium Library - altium_library.DbLib; Lifecycle Status: Active; Minimum Order: 1; Number of Terminations: 2; Operating Temperature: -55°C ~ 155°C; Package / Case: 0402 (1005 Metric); Packaging: CutTape; Part Number: RC0402FR-13100RP; PartId: 86079; Price: 0.01; Resistance: 100 Ohms; Series: RC_P; Size / Dimension: 0.039" L x 0.020" W (1.00mm x 0.50mm); Supplier 1: DigiKey; Supplier Device Package: 0402; Supplier Part Number 1: 13-RC0402FR-13100RPCT-ND; Tolerance: ±1%; Value: 100 Ohms
U1 = ADS1298: Component Kind: Standard; Supplier 1: Digi-Key; Supplier 2: Mouser; Supplier Part Number 1: 296-27734-ND; Supplier Part Number 2: 595-ADS1298IPAG; Library Name: Lobe_Lib.SchLib
LA1 LL1 RA1 RL1 SW_TP1-SW_TP4 = TestPoint: Component Kind: Standard; Description: TestPoint; Library Name: Lobe_Lib.SchLib
